# Altium schematic sheet USB.SchDoc
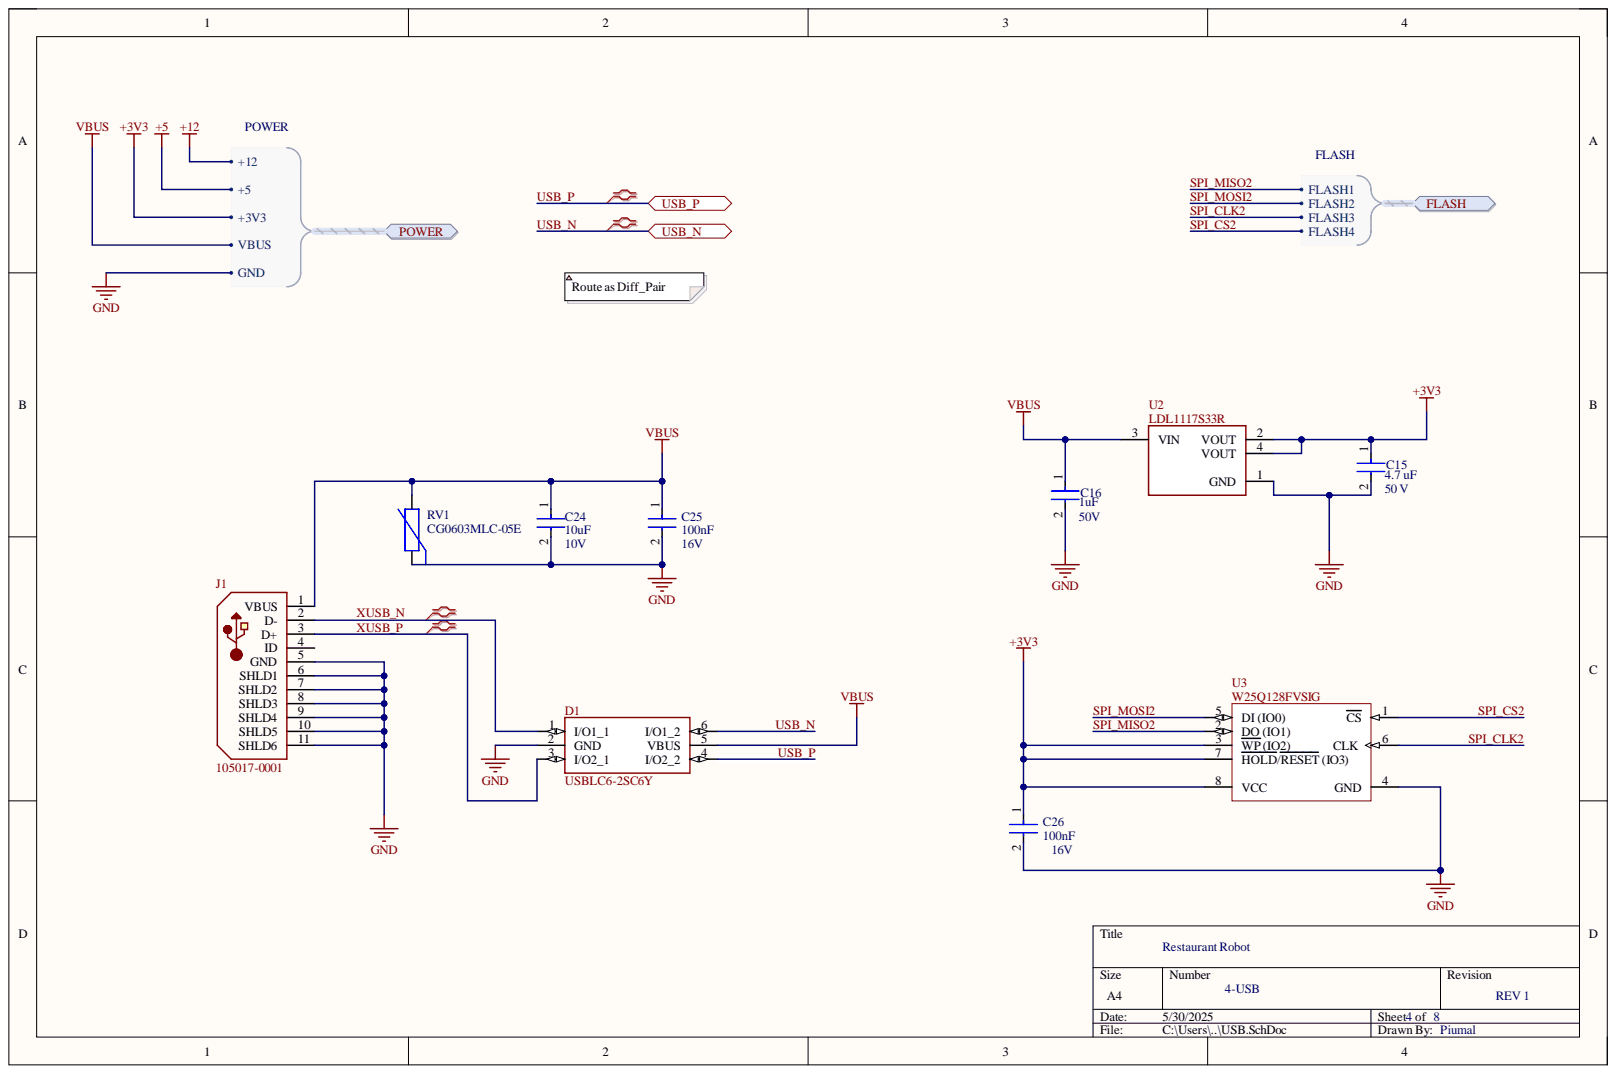
[diagram p0: USB.SchDoc]

## Parts
C15  value 4.7 uF  Capacitance: 4.7 uF  Voltage Rating: 50 V  [FP-CC1206-1_25-MFG]  (hidden comment: CC1206KKX7R9BB475)
C16  Capacitance: 1uF  Voltage Rating: 50V  [FP-1206-L_3_2_0_2-W_1_6_0-IPC_A]  (hidden comment: 12065C105KAT2A)
C24  Capacitance: 10uF  Voltage: 10V  [FP-1206-L_3_2_0_2-W_1_6_0-IPC_A]  (hidden comment: 1206ZD106KAT2A)
C25  Capacitance: 100nF  Voltage Rating: 16V  [FP-CL21-IPC_A]  (hidden comment: CL21B104KOANNNC)
C26  Capacitance: 100nF  Voltage Rating: 16V  [FP-CL21-IPC_A]  (hidden comment: CL21B104KOANNNC)
D1  USBLC6-2SC6Y  [SOT95P280X145-6N]
J1  105017-0001  [USB-MICRO-B_V]
RV1  CG0603MLC-05E  [BOUR-CG0603-2_V]
U2  LDL1117S33R  [FP-SOT223-0046067-IPC_C]
U3  W25Q128FVSIG  [WINB-SOIC-8_M]

## Ports
FLASH, FLASH.FLASH1, FLASH.FLASH2, FLASH.FLASH3, FLASH.FLASH4, POWER, POWER.+3V3, POWER.+5, POWER.+12, POWER.GND, POWER.VBUS, USB_N, USB_P

## Nets
+3V3: C15.1 C26.1 U2.2(VOUT) U2.4(VOUT) U3.3(WP_(IO2)) U3.7(HOLD/RESET_(IO3)) U3.8(VCC) ; port POWER
GND: C15.2 C16.2 C24.2 C25.2 C26.2 D1.2(GND) J1.5(GND) J1.6(SHLD1) J1.7(SHLD2) J1.8(SHLD3) J1.9(SHLD4) J1.10(SHLD5) J1.11(SHLD6) RV1.1 U2.1(GND) U3.4(GND) ; port POWER
SPI_CLK2: U3.6(CLK) ; port FLASH
SPI_CS2: U3.1(CS) ; port FLASH
SPI_MISO2: U3.2(DO_(IO1)) ; port FLASH
SPI_MOSI2: U3.5(DI_(IO0)) ; port FLASH
USB_N: D1.6(I/O1_2) ; port USB_N
USB_P: D1.4(I/O2_2) ; port USB_P
VBUS: C16.1 C24.1 C25.1 D1.5(VBUS) J1.1(VBUS) RV1.2 U2.3(VIN) ; port POWER
XUSB_N: D1.1(I/O1_1) J1.2(D-)
XUSB_P: D1.3(I/O2_1) J1.3(D+)
NetJ1_4: J1.4(ID)
+5: ; port POWER
+12: ; port POWER

## Library data of the parts
C15 = CMP-03422-000173-1: CAP CER 4.7UF 50V X7R 1206; Manufacturer: Yageo; Automotive Grade: NA; Material: Ceramic; Temperature Coefficient: 15%; Tolerance: 0.1; Automotive: No; Category: Cap; Component Kind: Standard; ComponentLink2Description: Datasheet; ComponentLink2URL: http://www.yageo.com/documents/recent/UPY-NP0X7R_HV_1KV-to-3KV_11.pdf; Device Class L1: Passive Components; Device Class L2: Capacitors; Device Class L3: Ceramic Capacitors; Digikey Description: CAP CER 4.7UF 50V X7R 1206; Footprint Url: http://www.yageo.com/exep/pages/download/literatures/UPY-C_GEN_24.pdf; Height: 1.45mm; Lead Free: Yes; Library Name: Altium Content Vault; Manufacturer Part Number: CC1206KKX7R9BB475; Mouser Description: CC Series 1206 4.7 uF 50 V ±10% Tolerance X7R Multilayer Ceramic Capacitor; Octopart: https://octopart.com/cc1206kkx7r9bb475-yageo-50417574; Package: CC1206-1.25; RoHS: TRUE; Temperature: -55°C to +125°C; Temperature Characteristic: X7R; Temperature Range High: +125°C; Temperature Range Low: -55°C
C16 = CMP-2000-05866-2: General Purpose Ceramic Capacitor, 1206, 1uF, 10%, X7R, 15%, 50V; Dissipation Factor: 2.5%; Voltage: 25V; Case Code (Imperial): 1206; Case Code (Metric): 3216; Case/Package: 1206; Component Kind: Standard; Construction: Flat; Contact Plating: Tin; Depth: 1.6mm; Dielectric: X7R; Height: 1.78mm; Lead Free: Lead Free; Length: 3.2mm; Library Name: Altium Content Vault; Max Operating Temperature: 125°C; Min Operating Temperature: -55°C; Mount: Surface Mount; Number of Pins: 2; Package Quantity: 1; Packaging: Tape and Reel; Radiation Hardening: No; REACH SVHC: No SVHC; RoHS Compliant: Yes; Series: -; Termination: SMD/SMT; Thickness: 0.07inch; Tolerance: 10%; Voltage Rating (DC): 50V; Width: 0.063inch
C24 = CMP-04427-021870-1: General Purpose Ceramic Capacitor, 1206, 10uF, 10%, X5R, 15%, 10V; Case Code (Imperial): 1206; Case Code (Metric): 3216; Case/Package: 1206; Component Kind: Standard; Construction: Flat; Contact Plating: Tin; Depth: 1.6mm; Dielectric: X5R; Height: 0.07mm; Lead Free: Lead Free; Length: 3.2mm; Library Name: Altium Content Vault; Max Operating Temperature: 85°C; Min Operating Temperature: -55°C; Mount: Surface Mount; Number of Pins: 2; Package Quantity: 1; Packaging: Tape and Reel; Radiation Hardening: No; REACH SVHC: No SVHC; RoHS Compliant: Yes; Series: -; Size: 1.78mm; Termination: SMD/SMT; Thickness: 0.07inch; Tolerance: 10%; Voltage Rating: 10V; Voltage Rating (DC): 10V; Width: 0.063inch
C25 C26 = CMP-13271-003679-1: CL21 Series 0805 100nF 16V ±10% Tolerance X7R Multilayer Ceramic Chip Capacitor; Case Code (Imperial): 0805; Case Code (Metric): 2012; Case/Package: 0805; Component Kind: Standard; Depth: 1.25mm; Dielectric: X7R; Height: 0.65mm; Lead Free: Lead Free; Length: 2mm; Library Name: Altium Content Vault; Max Operating Temperature: 125°C; Min Operating Temperature: -55°C; Mount: Surface Mount; Package Quantity: 1; Packaging: Tape and Reel; Radiation Hardening: No; Thickness: 0.03inch; Tolerance: 10%; Voltage Rating (DC): 16V; Width: 0.049inch
D1 = USBLC6-2SC6Y: 17V Clamp 5A (8/20µs) Ipp Tvs Diode Surface Mount SOT-23-6; MF: STMicroelectronics; Check_prices: https://www.snapeda.com/parts/USBLC6-2SC6Y/STMicroelectronics/view-part/?ref=eda; Component Kind: Standard; Library Name: USBLC6-2SC6Y.IntLib; MP: USBLC6-2SC6Y; Package: SOT-23-6 STMicroelectronics; SnapEDA_Link: https://www.snapeda.com/parts/USBLC6-2SC6Y/STMicroelectronics/view-part/?ref=snap
J1 = CMP-2000-05827-1: Micro-USB B Receptacle, Right Angle, Bottom Mount, Surface Mount, with Solder Tabs, -30 to 85 degC, 5-Pin USB, RoHS, Tape and Reel; Manufacturer: Molex; 3D Model: 105017-0001.stp; Catalog Drawings: 1050170001; Circuits: 5; Connector Type: USB-microB; Featured Product: Micro-USBConnectorsUSBConnectors; Mating Products: WM17145-ND-CABLEMICROUSBBTOSTDA1.0MWM17147-ND-CABLEMICROUSBBTOSTDA2.0MWM17146-ND-CABLEMICROUSBBTOSTDA1.5M; Online Catalog: Molex-MicroUSB; Other Names: WM1399DKR; Package Version: Rev. F, 12/2012; PCN Assembly/Origin: ResinSupplier21/Oct/2015; Voltage - Max: 30V; Category: Connectors,Interconnects; Component Kind: Standard; Datasheet URL: http://www.molex.com/elqNow/elqRedir.htm?ref=http://www.molex.com/webdocs/datasheets/pdf/en-us/1050170001_IO_CONNECTORS.pdf; Datasheet Version: 03/2016; Family: USB,DVI,HDMIConnectors; Features: Shielded,SolderRetention; Gender: Receptacle; Ingress Protection: -; Library Name: Altium Content Vault; Manufacturer URL: http://www.molex.com/; Mounting Technology: SurfaceMount; Mounting Type: BoardCutout,SurfaceMount,RightAngle; Number of Contacts: 5; Package Description: 5-Leads USB, Micro B, Body 7.5 x 5 mm, Pitch 0.65 mm; Package Reference: MICRO-B; Package URL: http://www.molex.com/pdm_docs/sd/1050170001_sd.pdf; Packaging: Digi-Reel®; RoHS Information: 1050170001CertofCompliance; Series: -; Specifications: -; Standard Package: 1
RV1 = CMP-0584-00001-2: ESD Protector, 5 V Supply, -40 to 85 degC, 1.6 x 0.8 x 0.55 mm SMD, RoHS, Tape and Reel; Manufacturer: Bourns; CO: 0.5 pF; RT: 1 ns; VC: 20 V; VDC: 5 V; VT: 150 V; Component Kind: Standard; ComponentLink1Description: Manufacturer URL; ComponentLink1URL: http://www.bourns.com/; ComponentLink2Description: Datasheet; ComponentLink2URL: http://www.bourns.com/data/global/pdfs/MLC.pdf; DatasheetVersion: Rev. S; Library Name: Altium Content Vault; Mounting Technology: Surface Mount; Operating Temperature: -40 to 85 degC; PackageDescription: 2-Pin SMD, Body 1.6 x 0.8 mm, Pitch 1.52 mm; PackageReference: CG0603-2; Packing: Tape and Reel; PartNumber: CG0603MLC-05E; RoHS: TRUE
U2 = CMP-12153-000006-1: IC REG LINEAR 3.3V 1.2A SOT223; Manufacturer: STMicroelectronics; Accuracy Percentage: 2%; Dropout Voltage: 350mV; Max Junction Temp: +125°C; Max Supply Voltage: 18V; Min Supply Voltage: 2.6V; Nominal Supply Current: 250uA; Number Of Outputs: 1; Output Current: 2A; Output Type: Fixed; Standoff Height: 0.02mm; Automotive: No; Category: IC; Component Kind: Standard; ComponentLink2Description: Datasheet; ComponentLink2URL: https://www.st.com/resource/en/datasheet/ldl1117.pdf; Device Class L1: Integrated Circuits (ICs); Device Class L2: Power Management ICs; Device Class L3: Voltage Regulators - Linear; Digikey Description: IC REG LINEAR 3.3V 1.2A SOT223; Height: 1.9mm; Ipc Land Pattern Name: SOT230P700X190-4; Lead Free: Yes; Library Name: Altium Content Vault; Manufacturer Part Number: LDL1117S33R; Mouser Description: LDL1117 Series 1.2 A 3.3 V High PSRR LDO Linear Voltage Regulator-SOT-223; Octopart: https://octopart.com/ldl1117s33r-stmicroelectronics-81876163; Output Voltage: 3.3V; Package: SOT223-0046067; RoHS: TRUE; Temperature: -40°C to +125°C; Temperature Range High: +125°C; Temperature Range Low: -40°C
U3 = CMP-2000-05005-1: 3V 128M-Bit Serial Flash Memory with Dual/Quad SPI & QPI, 2.7 to 3.6 V, -40 to 85 degC, 8-Pin SOIC, RoHS, Tape and Reel; Manufacturer: Winbond; Access Time (Max): 7ns; Address Bus: 24b; Boot Type: NotRequired; Cell Type: NOR; Density: 134217728Bit; Interface Type: Serial(SPI); Number of Words: 16777216; Operating Supply Voltage (Max): 3.6V; Operating Supply Voltage (Min): 2.7V; Operating Supply Voltage (Typ): 3/3.3V; Programmable: Yes; Rad Hardened: No; Supply Current: 20mA; Sync/Async: Synchronous; Word Size: 8b; Component Kind: Standard; Datasheet URL: http://download.siliconexpert.com/pdfs/2015/11/30/6/42/17/890/win_/manual/3w25q128fv20rev.l2008242015.pdf; Datasheet Version: Rev. L, 08/2015; Library Name: Altium Content Vault; Manufacturer URL: http://www.winbond.com/hq/index.html?__locale=en; Mounting: SurfaceMount; Operating Temp Range: -40Cto85C; Operating Temperature (Max): 85C; Operating Temperature (Min): -40C; Operating Temperature Classification: Industrial; Package Description: 8-Pin Small Outline Integrated Circuit, Body 5.28 x 5.28 mm, Pitch 1.27 mm; Package Reference: SS-8; Package Type: SOICW; Pin Count: 8; Program/Erase Volt (Typ): 2.7to3.6V
